# Altium schematic sheet Sheet1.SchDoc(Sheet1)
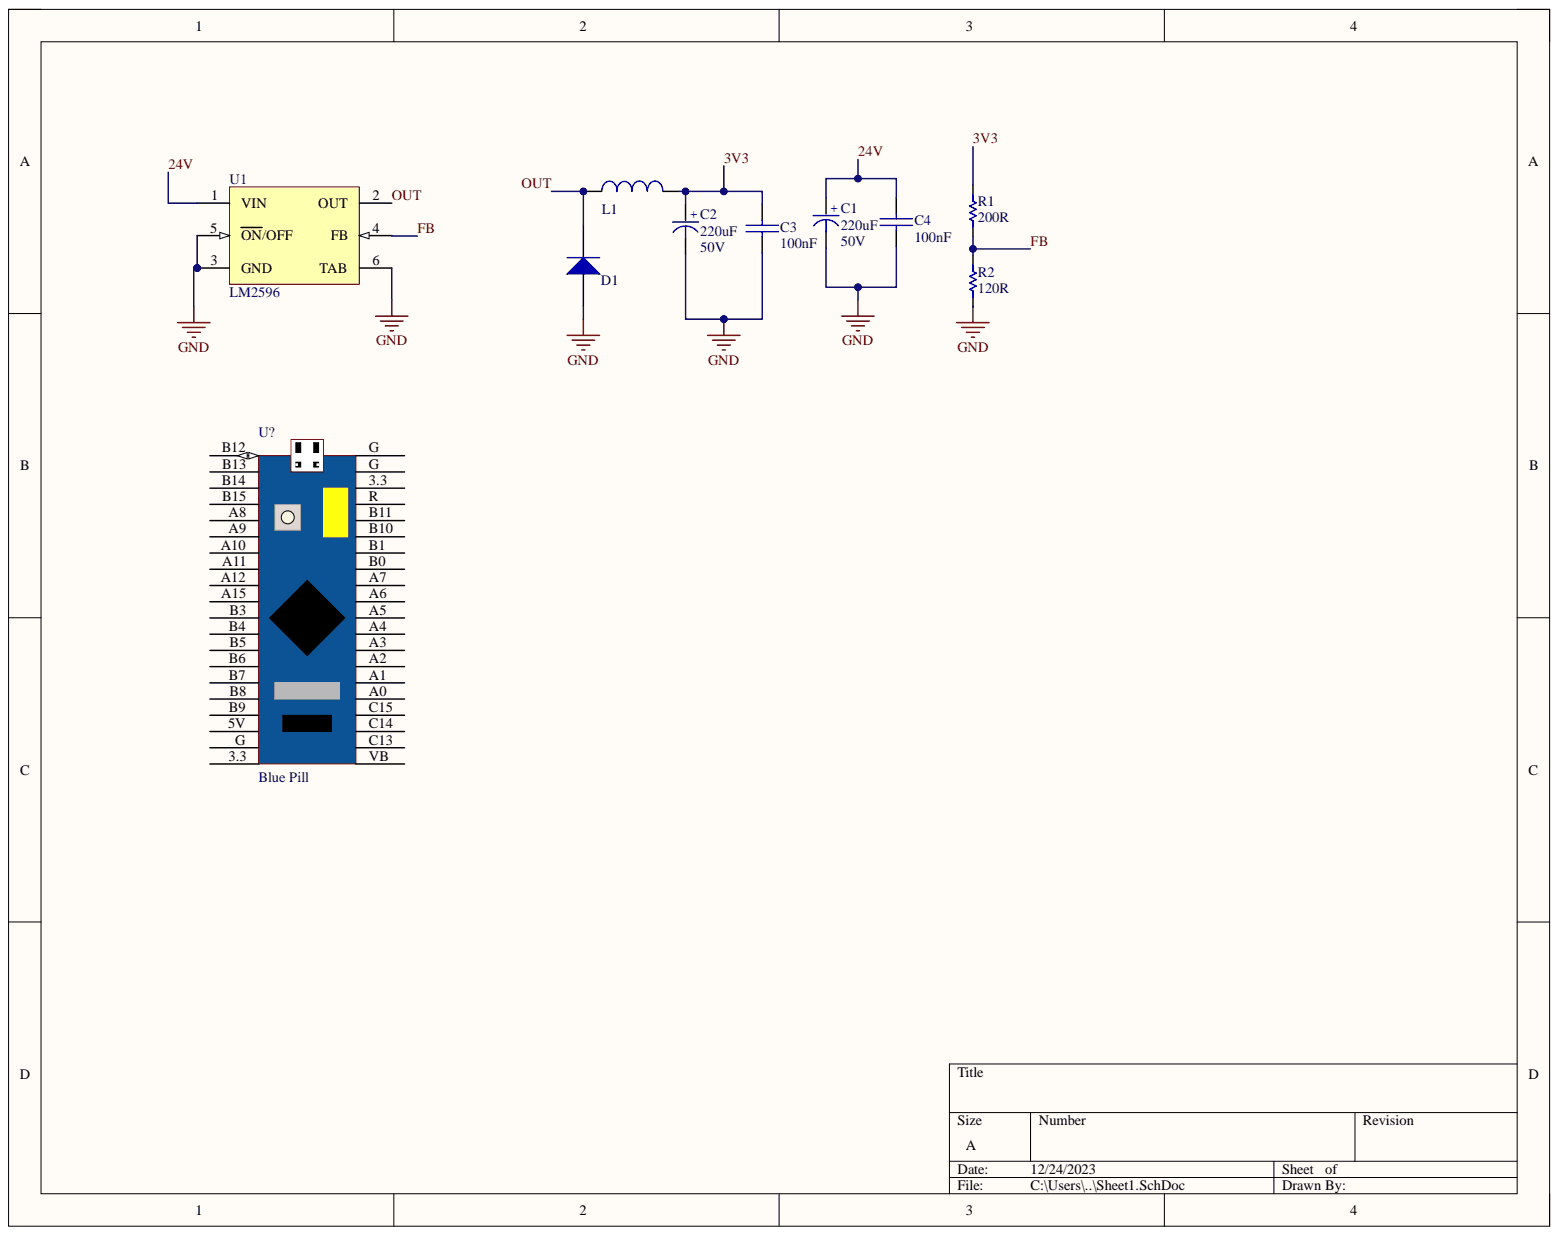
[diagram p0: Sheet1.SchDoc]

## Parts
C1  220uF  Voltage: 50V  [Cap_Al_9.3MM]
C2  220uF  Voltage: 50V  [Cap_Al_9.3MM]
C3  100nF  [SMD_CAP_1206]
C4  100nF  [SMD_CAP_1206]
D1  D?  [LL4148]
L1  Inductor  [induc-smd]  (value not drawn)
R1  200R  [SMD RES 1206]
R2  120R  [SMD RES 1206]
U1  LM2596  [LM2596 12V SMD]
U?  Blue Pill  [Blue Pill]

## Nets
GND: C1.2 C2.2 C3.2 C4.2 D1.1 R2.1 U1.3(GND) U1.5(ON/OFF) U1.6(TAB)
3V3: C2.1 C3.1 L1.2 R1.2
24V: C1.1 C4.1 U1.1(VIN)
FB: R1.1 R2.2 U1.4(FB)
OUT: D1.2 L1.1 U1.2(OUT)

Not connected: U? 3.3 5V A0 A1 A2 A3 A4 A5 A6 A7 A8 A9 A10 A11 A12 A15 B0 B1 B3 B4 B5 B6 B7 B8 B9 B10 B11 B12 B13 B14 B15 C13 C14 C15 G R VB

## Library data of the parts
C1 C2 = Cap-Polar: Polar Capacitor; Designer: Saeid Jorablo; Organazation: ControTec; Adding Date: 1387/12/20; Component Kind: Standard; Value: 220uF; Library Name: Behyaar_All_v1.IntLib
C3 C4 = Cap-Bipolar: Bipolar Capacitor; Designer: Saeid Jorablo; Organazation: ControTec; Voltage: 100V; Adding Date: 1387/12/20; Component Kind: Standard; Value: 100nF; Library Name: Behyaar_All_v1.IntLib
D1 = Diode: Component Kind: Standard; Library Name: Behyaar_All_v1.IntLib
R1 R2 = Resistor: Component Kind: Standard; Description: Resistor; LatestRevisionDate: 17-Jul-2002; LatestRevisionNote: Re-released for DXP Platform.; PackageDescription: Axial Device, Thru-Hole; 2 Leads; 0.3 in Pin Spacing; PackageReference: AXIAL-0.3; Published: 8-Jun-2000; Library Name: Behyaar_All_v1.IntLib; Publisher: Altium Limited
  R1: value: 200R
  R2: value: 120R
L1 = Inductor: Component Kind: Standard; Library Name: Behyaar_All_v1.IntLib
U1 = LM2596: Component Kind: Standard; Library Name: LM2596.SchLib
U? = Blue Pill: Component Kind: Standard; Library Name: Blue Pill.SchLib
